# Altium schematic sheet IO.SchDoc
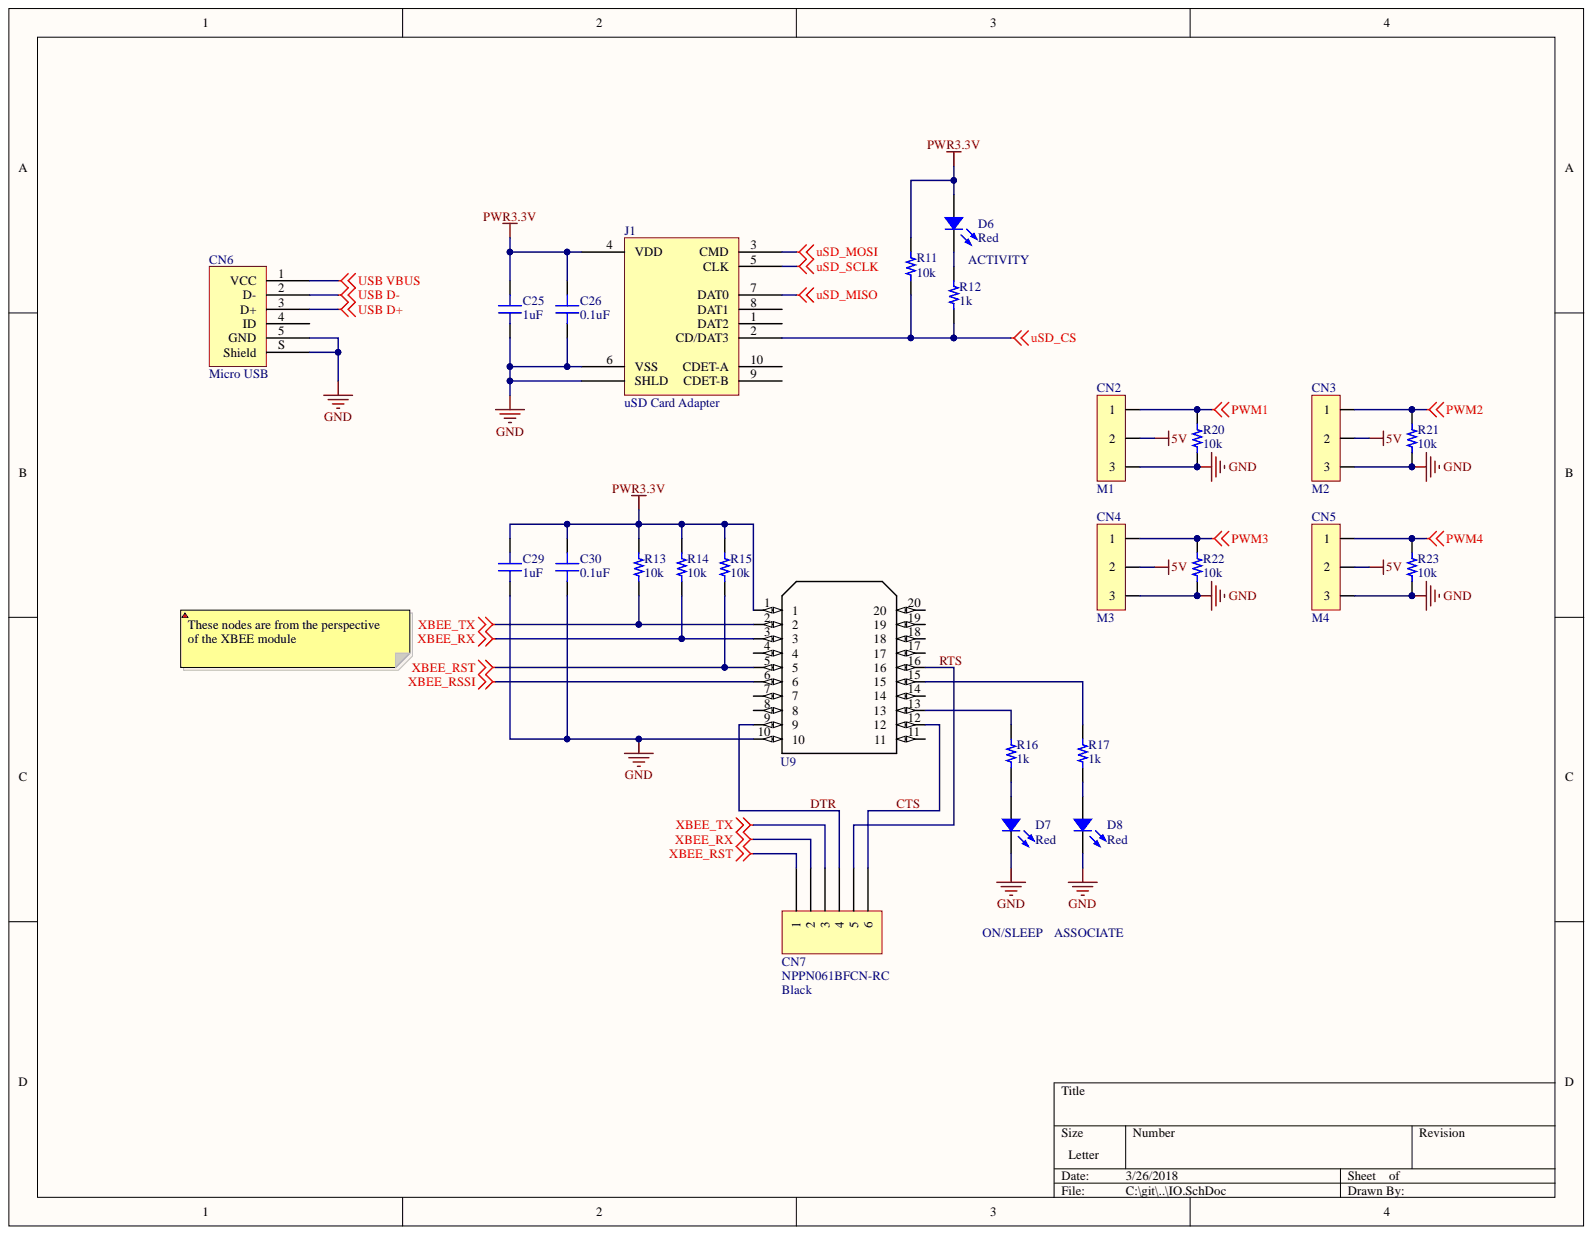
[diagram p0: IO.SchDoc]

## Parts
C25  1uF  [CAP 0603/1608]
C26  0.1uF  [CAP 0603/1608]
C29  1uF  [CAP 0603/1608]
C30  0.1uF  [CAP 0603/1608]
CN2  M1  [HEADER_1X3]
CN3  M2  [HEADER_1X3]
CN4  M3  [HEADER_1X3]
CN5  M4  [HEADER_1X3]
CN6  Micro USB  [FCI USB 10118193-0001LF]
CN7  NPPN061BFCN-RC  [SULLINS NPPN061BFCN]
D6  Red  [LED 0603/1608 RED]
D7  Red  [LED 0603/1608 RED]
D8  Red  [LED 0603/1608 RED]
J1  uSD Card Adapter  [HIROSE DM3AT-SF-PEJM5]
R11  10k  [RES 0603/1608]
R12  1k  [RES 0603/1608]
R13  10k  [RES 0603/1608]
R14  10k  [RES 0603/1608]
R15  10k  [RES 0603/1608]
R16  1k  [RES 0603/1608]
R17  1k  [RES 0603/1608]
R20  10k  [RES 0603/1608]
R21  10k  [RES 0603/1608]
R22  10k  [RES 0603/1608]
R23  10k  [RES 0603/1608]
U9  XB24CDMPIT-001  [DIP-20]  (value not drawn)

## Nets
5V: CN2.2 CN3.2 CN4.2 CN5.2
GND: C25.1 C26.1 C29.1 C30.1 CN2.3 CN3.3 CN4.3 CN5.3 CN6.5(GND) CN6.S(Shield) D7.2 D8.2 J1.6(VSS) J1.S(SHLD) R20.1 R21.1 R22.1 R23.1 U9.10
PWM1: CN2.1 R20.2
PWM2: CN3.1 R21.2
PWM3: CN4.1 R22.2
PWM4: CN5.1 R23.2
PWR3.3V: C25.2 C26.2 C29.2 C30.2 D6.1 J1.4(VDD) R11.2 R13.2 R14.2 R15.2 U9.1
USB D+: CN6.3(D+)
USB D-: CN6.2(D-)
USB VBUS: CN6.1(VCC)
uSD_CS: J1.2(CD/DAT3) R11.1 R12.1
uSD_MISO: J1.7(DAT0)
uSD_MOSI: J1.3(CMD)
uSD_SCLK: J1.5(CLK)
XBEE_RSSI: U9.6
XBEE_RST: CN7.1 R15.1 U9.5
XBEE_RX: CN7.2 R14.1 U9.3
XBEE_TX: CN7.3 R13.1 U9.2
CTS: CN7.6 U9.12
DTR: CN7.4 U9.9
RTS: CN7.5 U9.16
NetD6_2: D6.2 R12.2
NetD7_1: D7.1 R16.1
NetD8_1: D8.1 R17.1
NetR16_2: R16.2 U9.13
NetR17_2: R17.2 U9.15

Not connected: CN6 4(ID); J1 1(DAT2) 8(DAT1) 9(CDET-B) 10(CDET-A); U9 4 7 8 11 14 17 18 19 20

## Library data of the parts
C25 C26 C29 C30 = C1608X7R1A105K080AC: CAP CER 1UF 10V X7R 0603; Manufacturer: TDK Corporation; Database Table Name: Capacitor - Ceramic; TemperatureRange: -55C ~ 125C; Tolerance: 10%; Component Kind: Standard; ComponentLink1Description: Datasheet; ComponentLink1URL: https://product.tdk.com/info/en/catalog/datasheets/mlcc_commercial_general_en.pdf; ComponentLink2Description: Digi-Key listing; ComponentLink2URL: http://digikey.com/product-detail/en/C1608X7R1A105K080AC/445-5138-1-ND/2093753; Device Package: 0603 (1608 Metric); Library Name: Celestial Library Database.DbLib; PartId: 4035; Part Number: C1608X7R1A105K080AC; Price: 0.14; Supplier 1: Digi-Key; Supplier Part Number 1: 445-5138-1-ND; TemperatureCoefficient: X7R; Type: -; Voltage: 10V
  C25 C29: Value: 1uF
  C26 C30: Value: 0.1uF
CN2-CN5 = Header_1x3: Component Kind: Standard; Library Name: PinHeader.SchLib
CN6 = 10118193-0001LF: CONN USB MICRO B RECPT SMT R/A; Manufacturer: FCI; Database Table Name: Connector - USB; Number Of Positions: 5; Component Kind: Standard; ComponentLink1Description: Datasheet; ComponentLink1URL: http://portal.fciconnect.com/Comergent//fci/drawing/10118193.pdf; ComponentLink2Description: Digi-Key Listing; ComponentLink2URL: http://www.digikey.ca/product-detail/en/10118193-0001LF/609-4616-2-ND/2785388; Library Name: Celestial Library Database.DbLib; PartId: 7864; Part Number: 10118193-0001LF; Price: 0.2823; Series: USB 2.0; Supplier 1: Digi-Key; Supplier Part Number 1: 609-4616-2-ND; Type: USB - micro B
CN7 = NPPN061BFCN-RC: CONN RECEPT 2MM SINGLE STR 6POS; Manufacturer: Sullins Connector Solutions; Database Table Name: Connector - Rectangular; Number Of Positions: 6; Pitch: 0.079" (2.00mm); Colour: Black; Component Kind: Standard; ComponentLink1Description: Datasheet; ComponentLink1URL: http://www.sullinscorp.com/drawings/75_1BFC_10483.pdf; ComponentLink2Description: Digi-Key Listing; ComponentLink2URL: http://www.digikey.com/product-detail/en/sullins-connector-solutions/NPPN061BFCN-RC/S5751-06-ND/804808; Contact Finish: Gold; Fastening Type: -; Library Name: Celestial Library Database.DbLib; Number Of Rows: 1; PartId: 10885; Part Number: NPPN061BFCN-RC; Price: 1.01; Series: -; Supplier 1: Digi-Key; Supplier Part Number 1: S5751-06-ND; Type: Header
D6-D8 = LTST-C191KRKT: LED RED CLEAR 0603 SMD; Manufacturer: Lite-On Inc.; Current (Test): 20mA; Database Table Name: Chip LED; Millicandela: 54mcd; Value: Green; Viewing Angle: 130; Voltage Forward: 2V; Colour: Red; Component Kind: Standard; ComponentLink1Description: Datasheet; ComponentLink1URL: http://optoelectronics.liteon.com/upload/download/DS22-2000-223/S_110_LTST-C191KRKT.pdf; ComponentLink2Description: Digi-Key Listing; ComponentLink2URL: http://www.digikey.ca/product-detail/en/LTST-C191KRKT/160-1447-2-ND/386837; Device Package: 0603 (1608 Metric); Lens Transparency: Clear; Library Name: Celestial Library Database.DbLib; PartId: 7050; Part Number: LTST-C191KRKT; Price: 0.0521; Supplier 1: Digi-Key; Supplier Part Number 1: 160-1447-2-ND
J1 = DM3AT-SF-PEJM5: CONN MICRO SD CARD PUSH-PUSH R/A; Manufacturer: Hirose Electric Co Ltd; Connector Type: Connector and Ejector; Database Table Name: Connector - SD; Number of Positions: 10 (8 + 2); Component Kind: Standard; ComponentLink1Description: Datasheet; ComponentLink1URL: http://www.hirose.co.jp/cataloge_hp/e60900232.pdf; ComponentLink2Description: Digi-Key Listing; ComponentLink2URL: http://www.digikey.ca/product-detail/en/DM3AT-SF-PEJM5/HR1964TR-ND/2533565; Contact Finish: Gold; Features: Switch; Library Name: Celestial Library Database.DbLib; Mounting Type: Surface Mount, Right Angle; PartId: 9287; Part Number: DM3AT-SF-PEJM5; Price: 2.1114; Supplier 1: Digi-Key; Supplier Part Number 1: HR1964TR-ND; Type: Connector and Ejector
R11-R17 R20-R23 = ERJ-3EKF1002V: RES SMD 10K OHM 1% 1/10W 0603; Manufacturer: Panasonic Electronic Components; Database Table Name: Resistor - Chip; PowerRating: 0.1W, 1/10W; TemperatureCoefficient: 100ppm/C; TemperatureRange: -55C ~ 155C; Component Kind: Standard; ComponentLink1Description: Datasheet; ComponentLink1URL: http://industrial.panasonic.com/www-cgi/jvcr13pz.cgi?E+PZ+3+AOA0002+ERJ3EKF1002V+7+WW; ComponentLink2Description: Digi-Key listing; ComponentLink2URL: http://digikey.com/product-detail/en/ERJ-3EKF1002V/P10.0KHTR-ND/196066; Device Package: 0603 (1608 Metric); Library Name: Celestial Library Database.DbLib; PartId: 886; Part Number: ERJ-3EKF1002V; Price: 0.0038; Supplier 1: Digi-Key; Supplier Part Number 1: P10.0KHTR-ND; Tolerance: 1%; Type: Thick Film
  R11 R13-R15 R20-R23: Value: 10k
  R12 R16 R17: Value: 1k
U9 = XB24CDMPIT-001: XBEE S2C DIGIMESH 2.4 TH PCB ANT; MF: Digi International; Availability: Bad; Component Kind: Standard; Library Name: XB24CDMPIT-001.SchLib; MP: XB24CDMPIT-001; Package: Module Digi International; Price: 18.12 USD
